# Altium schematic sheet Power.SchDoc(Power)
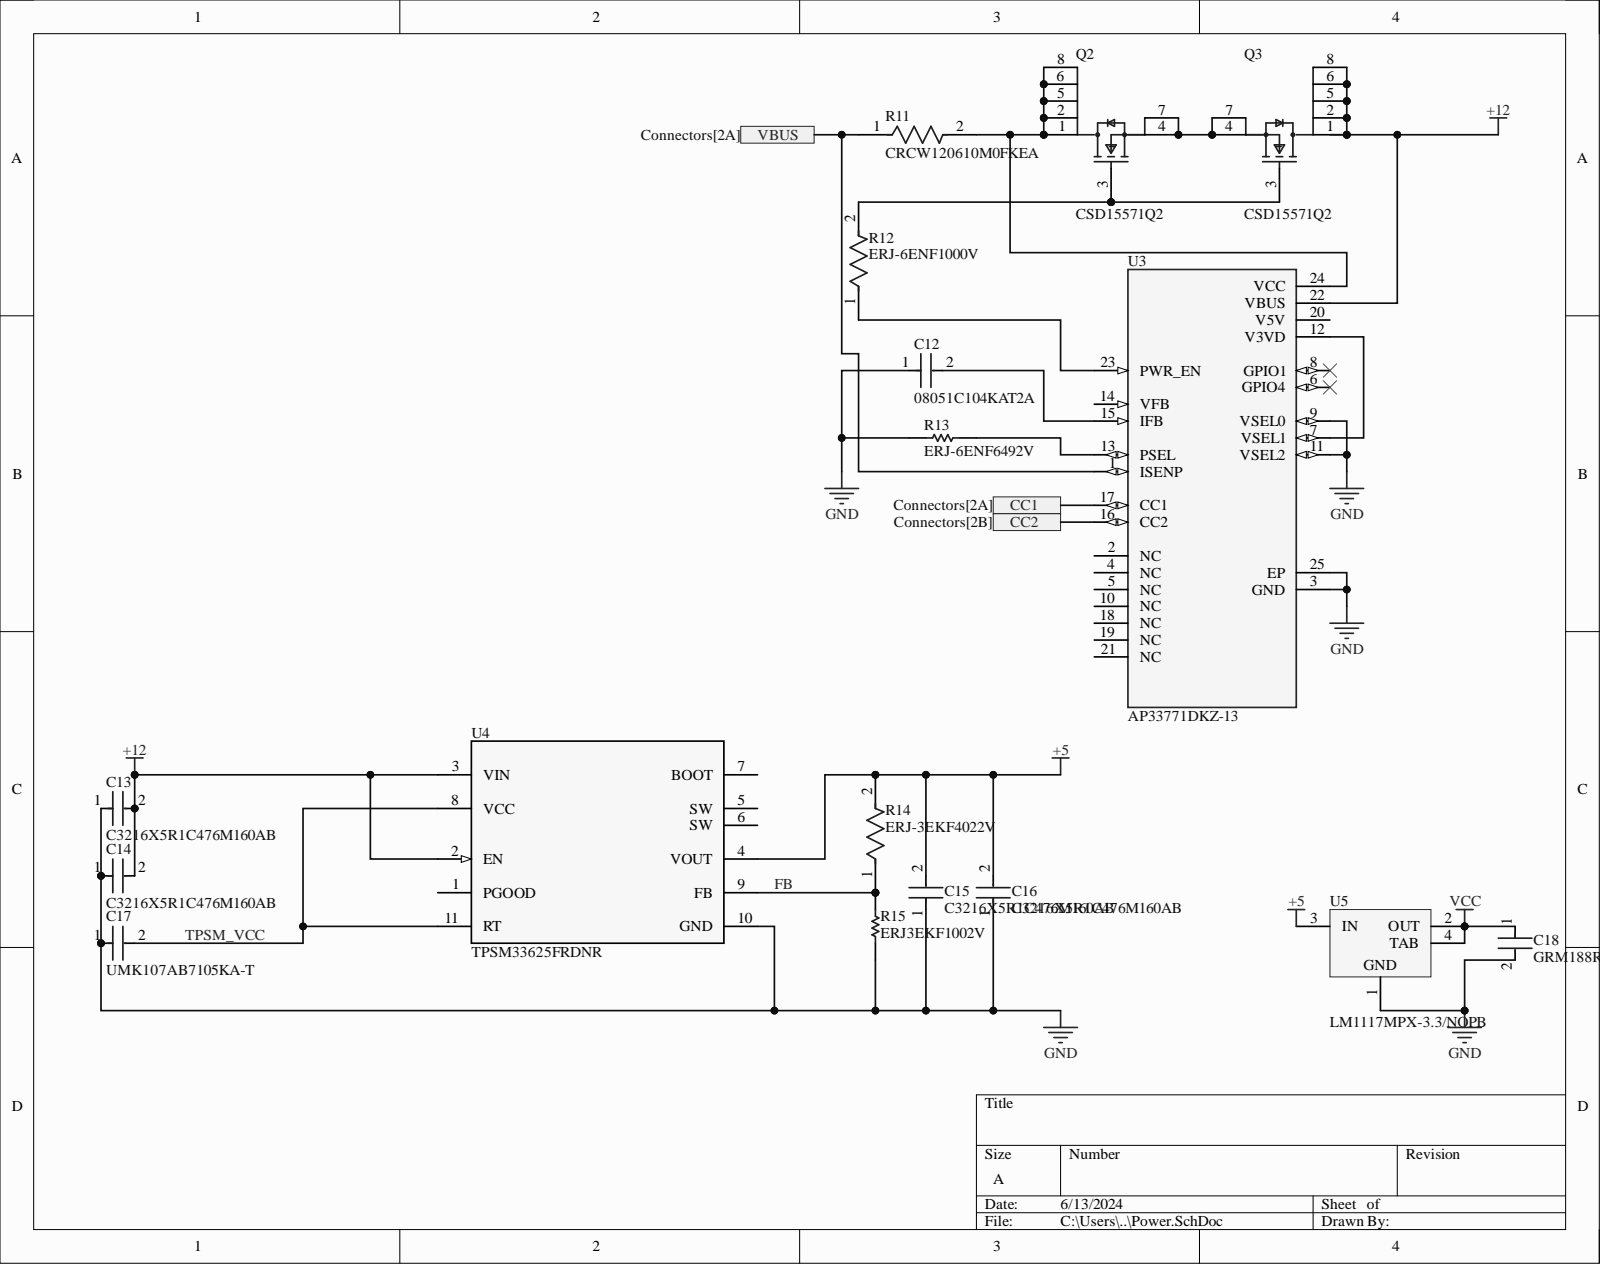
[diagram p0: Power.SchDoc]

## Parts
C12  08051C104KAT2A  [FP-0805-L_2_01_0_2-W_1_25-IPC_B]
C13  C3216X5R1C476M160AB  [FP-C3216-160-0_2-MFG]
C14  C3216X5R1C476M160AB  [FP-C3216-160-0_2-MFG]
C15  C3216X5R1C476M160AB  [FP-C3216-160-0_2-MFG]
C16  C3216X5R1C476M160AB  [FP-C3216-160-0_2-MFG]
C17  UMK107AB7105KA-T  [FP-0603-L_1_6_0_15-W_0_8-IPC_C]
C18  GRM188R60J476ME15D  [FP-GRM188-0_2-IPC_B]  (value not drawn)
Q2  CSD15571Q2  [FP-DQK0006C-MFG]
Q3  CSD15571Q2  [FP-DQK0006C-MFG]
R11  CRCW120610M0FKEA  [FP-CRCW1206-e3-IPC_B]
R12  ERJ-6ENF1000V  [FP-ERJ6EN-MFG]
R13  ERJ-6ENF6492V  [RESC2013X70X40LL20T20]
R14  ERJ-3EKF4022V  [FP-ERJ3EK-IPC_C]
R15  ERJ3EKF1002V  [RESC1609X55X30LL15T15]
U3  AP33771DKZ-13  [IC_AP33771DKZ-13]
U4  TPSM33625FRDNR  [RDN0011A-MFG]
U5  LM1117MPX-3.3/NOPB  [DCY0004A_L]

## Ports
CC1, CC2, VBUS

## Nets
+5: C15.2 C16.2 R14.2 U4.4(VOUT) U5.3(IN)
+12: C13.2 C14.2 Q3.1 Q3.2 Q3.5 Q3.6 Q3.8 U3.22(VBUS) U4.2(EN) U4.3(VIN)
GND: C12.1 C13.1 C14.1 C15.1 C16.1 C17.1 C18.2 R13.1 R15.1 U3.3(GND) U3.9(VSEL0) U3.11(VSEL2) U3.25(EP) U4.10(GND) U5.1
VCC: C18.1 U5.2(OUT) U5.4(TAB)
CC1: U3.17(CC1) ; port CC1
CC2: U3.16(CC2) ; port CC2
FB: R14.1 R15.2 U4.9(FB)
TPSM_VCC: C17.2 U4.8(VCC) U4.11(RT)
VBUS: R11.1 U3.1(ISENP) ; port VBUS
NetC12_2: C12.2 U3.15(IFB)
NetQ2_1: Q2.1 Q2.2 Q2.5 Q2.6 Q2.8 R11.2 U3.24(VCC)
NetQ2_3: Q2.3 Q3.3 R12.2
NetQ2_4: Q2.4 Q2.7 Q3.4 Q3.7
NetR12_1: R12.1 U3.23(PWR_EN)
NetR13_2: R13.2 U3.13(PSEL)
NetU3_7: U3.7(VSEL1) U3.12(V3VD)

Not connected: U3 2(NC) 4(NC) 5(NC) 6(GPIO4) 8(GPIO1) 10(NC) 14(VFB) 18(NC) 19(NC) 20(V5V) 21(NC); U4 1(PGOOD) 5(SW) 6(SW) 7(BOOT)

## Library data of the parts
C12 = CMP-2007-04174-2: General Purpose Ceramic Capacitor, 0805, 100nF, 10%, X7R, 15%, 100V; Capacitance: 100nF; Case Code (Imperial): 0805; Case Code (Metric): 2012; Case/Package: 0805; Component Kind: Standard; Construction: Flat; Contact Plating: Tin; Depth: 1.25mm; Dielectric: X7R; Dielectric Material: Ceramic; Height: 1.4mm; Lead Free: Lead Free; Length: 2.01mm; Library Name: Altium Content Vault; Max Operating Temperature: 125°C; Min Operating Temperature: -55°C; Mount: Surface Mount; Number of Pins: 2; Package Quantity: 2000; Packaging: Tape and Reel; Radiation Hardening: No; REACH SVHC: No SVHC; RoHS Compliant: Yes; Series: -; Termination: SMD/SMT; Thickness: 0.055inch; Tolerance: 10%; Voltage: 100V; Voltage Rating: 100V; Voltage Rating (DC): 100V; Width: 0.049inch
C13-C16 = CMP-2000-07442-2: Multilayer Ceramic Capacitors 47uF ±20% 16V X5R SMD 1206; Capacitance: 47uF; Case Code (Imperial): 1206; Case Code (Metric): 3216; Case/Package: 1206; Component Kind: Standard; Construction: Flat; Depth: 1.6mm; Dielectric: X5R; Dielectric Material: Ceramic; Height: 1.6mm; Lead Free: Lead Free; Length: 3.2mm; Library Name: Altium Content Vault; Max Operating Temperature: 85°C; Min Operating Temperature: -55°C; Mount: Surface Mount; Package Quantity: 1; Packaging: Tape and Reel; Radiation Hardening: No; REACH SVHC: No SVHC; Resistor Type: Ceramic; RoHS Compliant: Yes; Series: C; Termination: SMD/SMT; Thickness: 0.071inch; Tolerance: 20%; Voltage Rating: 16V; Voltage Rating (DC): 16V; Weight: 0.000571oz; Width: 0.063inch
C17 = CMP-2000-07531-4: Ceramic Capacitor General Use 1uF ±10% 50V X7R 0603; Capacitance: 1uF; Case Code (Imperial): 0603; Case Code (Metric): 1608; Case/Package: 0603; Component Kind: Standard; Construction: Flat; Depth: 0.8mm; Dielectric: X7R; Halogen Free: Halogen Free; Height: 0.8mm; Length: 1.6mm; Library Name: Altium Content Vault; Max Operating Temperature: 125°C; Min Operating Temperature: -55°C; Mount: Surface Mount; Package Quantity: 1; Packaging: Tape and Reel; Radiation Hardening: No; RoHS Compliant: Yes; Series: M; Termination: SMD/SMT; Thickness: 0.037inch; Tolerance: 10%; Voltage Rating: 50V; Voltage Rating (DC): 50V; Width: 0.031inch
C18 = CMP-2000-05444-2: Chip Multilayer Ceramic Capacitors for General Purpose, 0603, 47uF, X5R, 15%, 20%, 6.3V; Capacitance: 47uF; Case Code (Imperial): 0603; Case Code (Metric): 1608; Case/Package: 0603; Component Kind: Standard; Dielectric: X5R; Length: 0.063inch; Library Name: Altium Content Vault; Max Operating Temperature: 85°C; Min Operating Temperature: -55°C; Mount: Surface Mount; Packaging: Tape and Reel; Thickness: 0.039inch; Tolerance: 20%; Voltage Rating: 6.3V; Voltage Rating (DC): 6.3V; Width: 0.031inch
Q2 Q3 = CMP-04962-000051-1: MOSFET N-CH 20V 22A 6SON; Drain to Source Resistance: 19.2mR; Element Configuration: Single; Fall Time: 4.1ns; Input Capacitance: 419pF; Max Power Dissipation: 2.5W; Number of Channels: 1; Number of Elements: 2; Rds On Max: 15mR; Rise Time: 17.2ns; Turn-Off Delay Time: 9.9ns; Component Kind: Standard; Contact Plating: Tin; Continuous Drain Current (ID): 22A; Drain to Source Breakdown Voltage: 20V; Drain to Source Voltage (Vdss): 20V; Gate to Source Voltage (Vgs): 20V; Lead Free: Lead Free; Library Name: Altium Content Vault; Max Operating Temperature: 150°C; Min Operating Temperature: -55°C; Mount: Surface Mount; Number of Pins: 6; Package Quantity: 3000; Packaging: Tape and Reel; Power Dissipation: 2.5W; Turn-On Delay Time: 17.2ns
R11 = CMP-1014-00962-3: RES Thick Film, 10MΩ, 1%, 0.25W, 100ppm/°C, 1206; Max Power Dissipation: 250mW; Resistance: 10MR; Voltage Rating: 200V; Case Code (Imperial): 1206; Case Code (Metric): 3216; Case/Package: 1206; Component Kind: Standard; Composition: Thick Film; Contact Plating: Tin; Depth: 1.6mm; Features: Automotive AEC-Q200; Height: 0.024inch; Lead Free: Lead Free; Length: 3.2mm; Library Name: Altium Content Vault; Max Operating Temperature: 155°C; Military Standard: Not; Min Operating Temperature: -55°C; Number of Terminations: 2; Package Quantity: 10; Packaging: Tape and Reel; Power Rating: 250mW; Radiation Hardening: No; Temperature Coefficient: 100ppm/°C; Termination: SMD/SMT; Tolerance: 1%; Voltage Rating (DC): 200V; Weight: 0.000353oz; Width: 0.063inch; Working Voltage: 200V
R12 = CMP-2001-04374-6: RES SMD 100 OHM 1% 1/8W 0805; Max Power Dissipation: 125mW; Resistance: 100R; Temperature Coefficient: 100ppm/°C; Voltage Rating: 150V; Case Code (Imperial): 0805; Case Code (Metric): 2012; Case/Package: 0805; Component Kind: Standard; Construction: Rectangular; Contact Plating: Tin; Depth: 1.25mm; Features: Automotive AEC-Q200; Height: 0.6mm; Lead Free: Lead Free; Length: 2mm; Library Name: Altium Content Vault; Max Operating Temperature: 155°C; Military Standard: Not; Min Operating Temperature: -55°C; Mount: Surface Mount; Number of Pins: 2; Number of Terminations: 2; Package Quantity: 1; Packaging: Tape and Reel; Power Rating: 125mW; Radiation Hardening: No; REACH SVHC: No SVHC; Resistance Tolerance: 1%; Resistor Type: Thick Film; RoHS Compliant: Yes; Series: ERJ; Size Code: 0805; Technology: THICK FILM; Termination: SMD/SMT; Tolerance: 1%; Voltage Rating (DC): 150V; Width: 1.2mm
R13 = CMP-2001-00264-1: Manufacturer: Panasonic; Component Kind: Standard; Library Name: Altium Content Vault; Part Number: ERJ-6ENF6492V
R14 = CMP-2000-00486-2: RES SMD 40.2K OHM 1% 1/10W 0603; Max Power Dissipation: 100mW; Resistance: 40.2kR; Temperature Coefficient: 100ppm/°C; Voltage Rating: 75V; Voltage Rating (DC): 50V; Case Code (Imperial): 0603; Case Code (Metric): 1608; Case/Package: 0603; Component Kind: Standard; Construction: Rectangular; Contact Plating: Tin; Depth: 0.8mm; Features: Automotive AEC-Q200; Height: 0.45mm; Lead Free: Lead Free; Length: 1.6mm; Library Name: Altium Content Vault; Max Operating Temperature: 155°C; Military Standard: Not; Min Operating Temperature: -55°C; Mount: Surface Mount; Number of Terminations: 2; Package Quantity: 1; Packaging: Tape and Reel; Power Rating: 100mW; Radiation Hardening: No; Resistance Tolerance: 1%; Resistor Type: Thick Film; RoHS Compliant: Yes; Series: ERJ; Technology: THICK FILM; Termination: SMD/SMT; Tolerance: 1%; Weight: 7.1E-05oz; Width: 0.031inch
R15 = CMP-1012-00623-3: Chip Resistor, 10 KOhm, +/- 1%, 0.1 W, -55 to 155 degC, 0603 (1608 Metric), RoHS, Tape and Reel; Manufacturer: Panasonic; Case\/Package: 0603; Max Power Dissipation: 100mW; Resistance: 10KOhm; Temperature Coefficient: 100ppm\/degreesC; Voltage Rating: 75V; Case Code (Imperial): 0603; Case Code (Metric): 1608; Component Kind: Standard; Composition: ThickFilm; Contact Plating: Tin; Datasheet URL: https://industrial.panasonic.com/cdbs/www-data/pdf/RDA0000/AOA0000C304.pdf; Datasheet Version: 02/2017; Depth: 0.8mm; Height: 0.45mm; Lead Free: LeadFree; Length: 1.6mm; Library Name: Altium Content Vault; Manufacturer URL: http://www.panasonic.com/; Max Operating Temperature: 155degC; Military Standard: Not; Min Operating Temperature: -55degC; Mount: SurfaceMount; Mounting Technology: SurfaceMount; Number of Pins: 2; Number of Terminations: 2; Package Description: 2-Pin Surface Mount Device, Body 1.6 x 0.85 mm; Package Quantity: 1; Package Reference: 603; Packaging: TapeandReel; Power Rating: 100mW; Radiation Hardening: No; REACH SVHC: NoSVHC; RoHSCompliant: true; Termination: SMD/SMT; Tolerance: 1%; Voltage Rating (DC): 75V; Width: 0.031inch
U3 = AP33771DKZ-13: MF: {'manufacturer': u'Diodes Incorporated'}; Availability: Unavailable; Component Kind: Standard; MP: AP33771DKZ-13; Package: None; Price: None
U4 = CMP-054-000002-4: No Description Available; Mfr_Name: Texas Instruments; Component Kind: Standard; Design Item ID: TPSM33625FRDNR; RefDes: RefDes; Source: TPSM33625FRDNR.SchLib; TYPE: TYPE; Library Name: Purdue University
U5 = CMP-0062-01639-4: 800mA Low-Dropout Linear Regulator, 4-pin SOT-223, Pb-Free; Manufacturer: Texas Instruments; CompType: LDO IC; High-Reliability: Y; PackageTopMarking: XYTT*N05A; PowerWise: N; Code_IPC: SOT223-4; Code_JEDEC: TO-261-AA; Component Kind: Standard; ComponentLink1Description: Datasheet URL; ComponentLink1URL: http://www.ti.com/general/docs/lit/getliterature.tsp?genericPartNumber=LM1117-N&fileType=pdf; Library Name: Altium Content Vault; PackageDescription: Molded SOT-223, 6.50 x 3.56 x 1.6mm Body, 4 Lead, 2.3mm Pitch; PackageReference: DCY0004A; PartNumber: LM1117MPX-3.3/NOPB; PinCount: 4; RoHS: Y
